# Altium schematic sheet Pedal Board - ADC.SchDoc("Pedal Board - ADC")
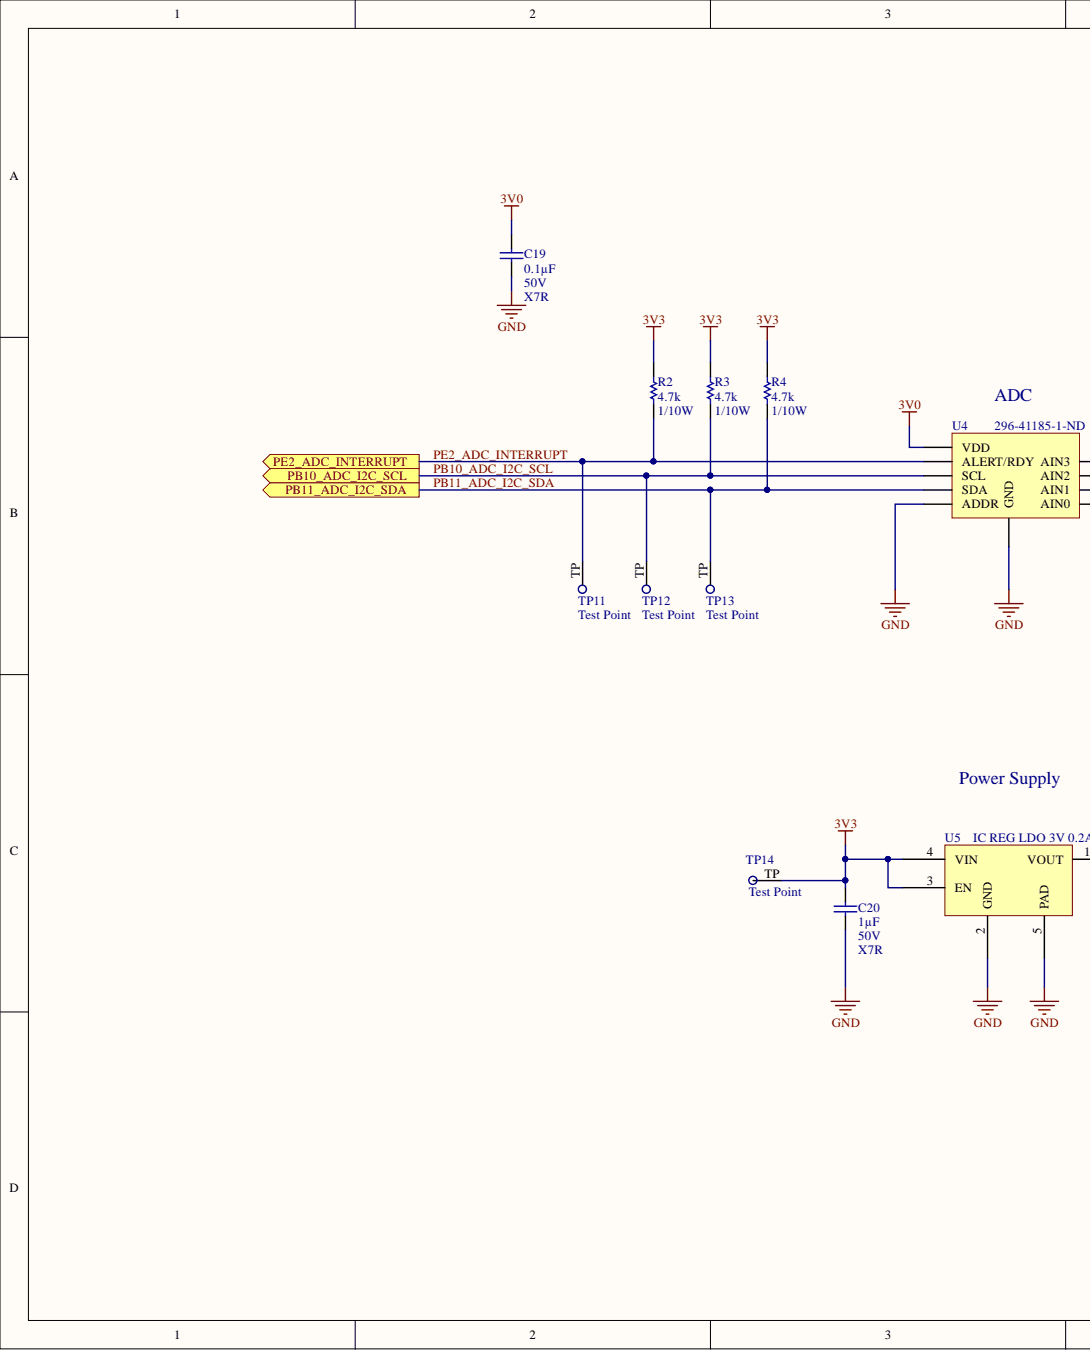
[diagram p0: Pedal Board - ADC.SchDoc, left]
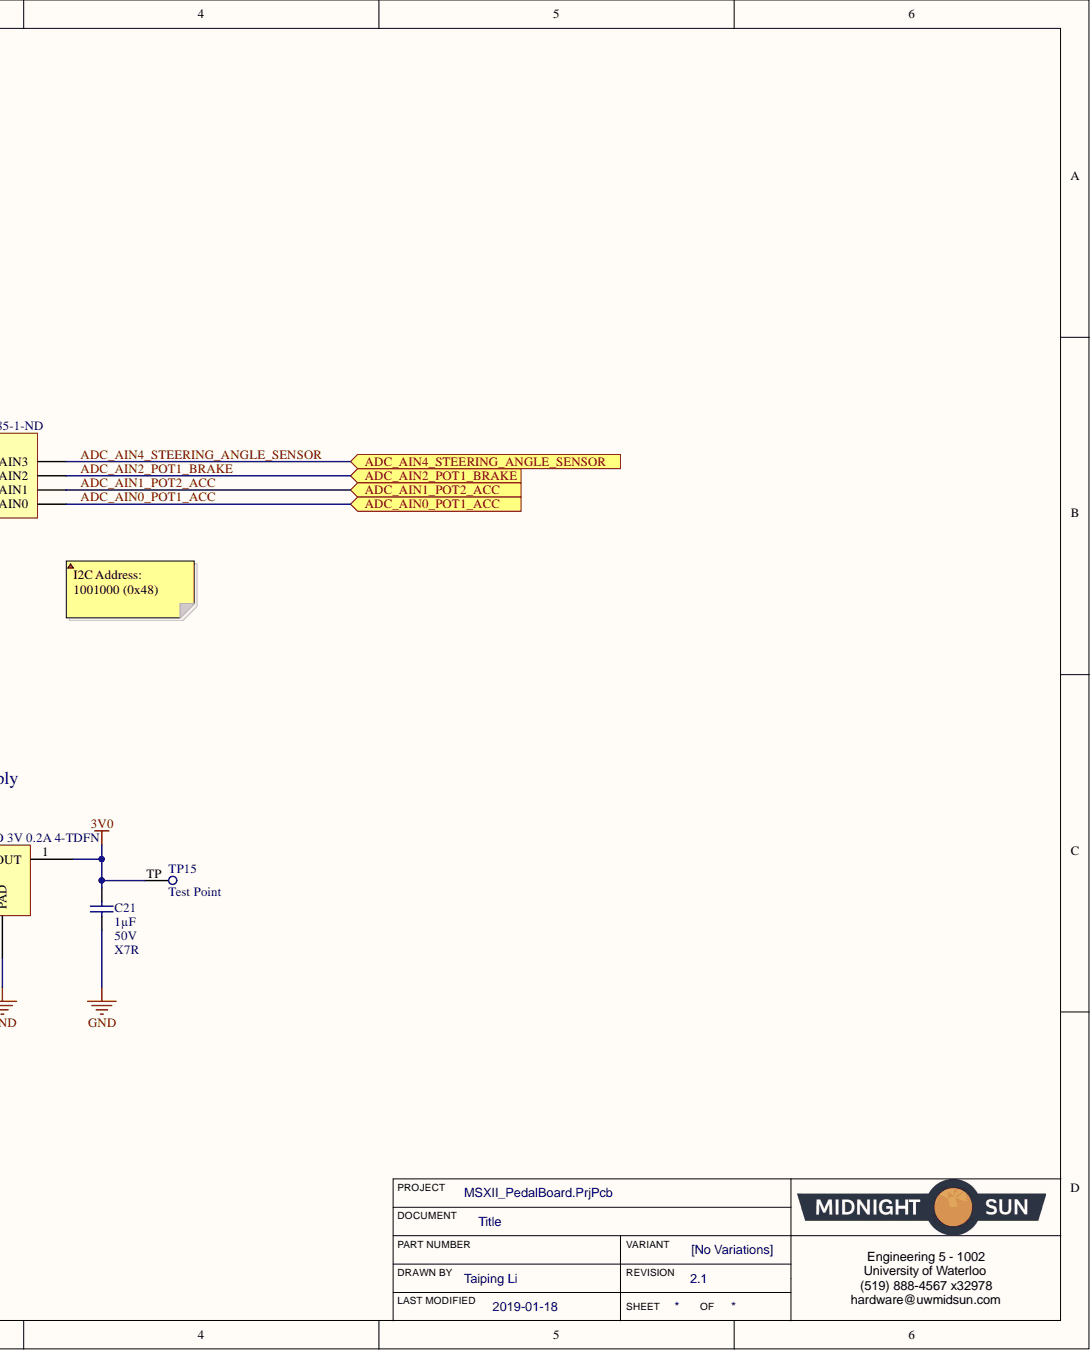
[diagram p1: Pedal Board - ADC.SchDoc, right]

## Parts
C19  Voltage: 50V  Temperature Coefficient: X7R  Capacitance: 0.1µF  [CAP, 0603]  (hidden comment: CAP CER 0.1UF 50V 10% X7R 0603)
C20  Voltage: 50V  Temperature Coefficient: X7R  Capacitance: 1µF  [CAP, 0603]  (hidden comment: CAP CER 1UF 50V 10% X7R 0603)
C21  Voltage: 50V  Temperature Coefficient: X7R  Capacitance: 1µF  [CAP, 0603]  (hidden comment: CAP CER 1UF 50V 10% X7R 0603)
R2  Resistance (Ohms): 4.7k  Power (Watts): 1/10W  [RES, 0603]  (hidden comment: RES 4.7K OHM 1% 1/10W 0603)
R3  Resistance (Ohms): 4.7k  Power (Watts): 1/10W  [RES, 0603]  (hidden comment: RES 4.7K OHM 1% 1/10W 0603)
R4  Resistance (Ohms): 4.7k  Power (Watts): 1/10W  [RES, 0603]  (hidden comment: RES 4.7K OHM 1% 1/10W 0603)
TP11  Test Point  [Test Point]  (no BOM)
TP12  Test Point  [Test Point]  (no BOM)
TP13  Test Point  [Test Point]  (no BOM)
TP14  Test Point  [Test Point]  (no BOM)
TP15  Test Point  [Test Point]  (no BOM)
U4  Supplier Part Number 1: 296-41185-1-ND  [IC ADC VSSOP10]  (hidden comment: Quad ADC)
U5  IC REG LDO 3V 0.2A 4-TDFN  Voltage - Output: 3V  [IC REG LDO 3V 0.2A 4-TDFN]

## Ports
ADC_AIN0_POT1_ACC, ADC_AIN1_POT2_ACC, ADC_AIN2_POT1_BRAKE, ADC_AIN4_STEERING_ANGLE_SENSOR, PB10_ADC_I2C_SCL, PB11_ADC_I2C_SDA, PE2_ADC_INTERRUPT

## Nets
3V0: C19.1 C21.1 TP15.TP U4.8(VDD) U5.1(VOUT)
3V3: C20.1 R2.1 R3.1 R4.1 TP14.TP U5.3(EN) U5.4(VIN)
GND: C19.2 C20.2 C21.2 U4.1(ADDR) U4.3(GND) U5.2(GND) U5.5(PAD)
ADC_AIN0_POT1_ACC: U4.4(AIN0) ; port ADC_AIN0_POT1_ACC
ADC_AIN1_POT2_ACC: U4.5(AIN1) ; port ADC_AIN1_POT2_ACC
ADC_AIN2_POT1_BRAKE: U4.6(AIN2) ; port ADC_AIN2_POT1_BRAKE
ADC_AIN4_STEERING_ANGLE_SENSOR: U4.7(AIN3) ; port ADC_AIN4_STEERING_ANGLE_SENSOR
PB10_ADC_I2C_SCL: R3.2 TP12.TP U4.10(SCL) ; port PB10_ADC_I2C_SCL
PB11_ADC_I2C_SDA: R4.2 TP13.TP U4.9(SDA) ; port PB11_ADC_I2C_SDA
PE2_ADC_INTERRUPT: R2.2 TP11.TP U4.2(ALERT/RDY) ; port PE2_ADC_INTERRUPT

## Library data of the parts
C19 = CAP CER 0.1UF 50V 10% X7R 0603: 0.10µF ±10% 50V Ceramic Capacitor X7R 0603 (1608 Metric); Manufacturer Part Number 1: 06035C104KAT2A; Manufacturer 1: AVX Corporation; Tolerance: ±10%; Thickness (Max): 0.035" (0.90mm); Supplier Part Number 1: 478-5052-1-ND; Supplier 1: Digi-Key; Size / Dimension: 0.063" L x 0.032" W (1.60mm x 0.81mm); Ratings: -; Part Status: Active; Packaging: Cut Tape (CT); Package / Case: 0603 (1608 Metric); Operating Temperature: -55°C ~ 125°C; Mounting Type: Surface Mount, MLCC; Lead Style: -; Lead Spacing: -; Height - Seated (Max): -; ComponentLink1URL: http://datasheets.avx.com/X7RDielectric.pdf; ComponentLink1Description: http://datasheets.avx.com/X7RDielectric.pdf; Component Kind: Standard; Library Name: Schematic Diagrams.SchLib
C20 C21 = CAP CER 1UF 50V 10% X7R 0603: 1µF ±10% 50V Ceramic Capacitor X7R 0603 (1608 Metric); Manufacturer Part Number 1: UMK107AB7105KA-T; Manufacturer 1: Taiyo Yuden; Tolerance: ±10%; Thickness (Max): 0.037" (0.95mm); Supplier Part Number 1: 587-3247-1-ND; Supplier 1: Digi-Key; Size / Dimension: 0.063" L x 0.031" W (1.60mm x 0.80mm); Ratings: -; Part Status: Active; Packaging: Cut Tape (CT); Package / Case: 0603 (1608 Metric); Operating Temperature: -55°C ~ 125°C; Mounting Type: Surface Mount, MLCC; Lead Style: -; Lead Spacing: -; Height - Seated (Max): -; ComponentLink1URL: http://www.yuden.co.jp/productdata/catalog/en/mlcc_all_e.pdf; ComponentLink1Description: http://www.yuden.co.jp/productdata/catalog/en/mlcc_all_e.pdf; Component Kind: Standard; Library Name: Schematic Diagrams.SchLib
R2-R4 = RES 4.7K OHM 1% 1/10W 0603: 4.7k Ohm ±1% 0.1W, 1/10W Chip Resistor 0603 (1608 Metric) Moisture Resistant Thick Film; Manufacturer Part Number 1: RC0603FR-074K7L; Manufacturer 1: Yageo; Temperature Coefficient: ±100ppm/°C; Description 1: RES SMD 4.7K OHM 1% 1/10W 0603; Tolerance: ±1%; Supplier Part Number 1: 311-4.70KHRCT-ND; Supplier Device Package: 0603; Supplier 1: Digi-Key; Stock 1: 3487566; Size / Dimension: 0.063" L x 0.031" W (1.60mm x 0.80mm); RoHS: RoHS Compliant; Pricing 1: 1=0.16, 10=0.022, 100=0.0089, 1000=0.00402, 2500=0.00349 (CAD); Part Status: Active; Packaging: Cut Tape (CT); Package / Case: 0603 (1608 Metric); Operating Temperature: -55°C ~ 155°C; Height - Seated (Max): 0.022" (0.55mm); Features: Moisture Resistant; Failure Rate: -; Composition: Thick Film; ComponentLink1URL: http://www.yageo.com/documents/recent/PYu-RC_Group_51_RoHS_L_7.pdf; ComponentLink1Description: http://www.yageo.com/documents/recent/PYu-RC_Group_51_RoHS_L_7.pdf; Component Kind: Standard; Category: Resistors; Library Name: Schematic Diagrams.SchLib
U4 = IC ADC 12-BIT VSSOP-10: 12 Bit Analog to Digital Converter 4 Input 1 Sigma-Delta 10-VSSOP; Manufacturer Part Number: ADS1015IDGSR; Manufacturer: Texas Instruments; Voltage - Supply, Digital: 2 V ~ 5.5 V; Sampling Rate (Per Second): 3.3k; Reference Type: Internal; Number of Inputs: 2, 4; Number of Bits: 12; Number of A/D Converters: 1; Input Type: Differential, Single Ended; Data Interface: I²C; Configuration: MUX-PGA-ADC; Voltage - Supply, Analog: 2 V ~ 5.5 V; Supplier Device Package: 10-VSSOP; Supplier 1: Digi-Key; Stock 1: 4084; RoHS: RoHS Compliant; Ratio - S/H: -; Pricing 1: 1=4.25, 10=3.807, 100=3.1194, 500=2.65552, 1000=2.23959 (CAD); Part Status: Active; Packaging: Cut Tape (CT); Package / Case: 10-TFSOP, 10-MSOP (0.118", 3.00mm Width); Operating Temperature: -40°C ~ 125°C; Features: PGA, Selectable Address; ComponentLink1URL: http://www.ti.com/lit/ds/symlink/ads1013.pdf; ComponentLink1Description: http://www.ti.com/lit/ds/symlink/ads1013.pdf; Component Kind: Standard; Category: Integrated Circuits (ICs); Architecture: Sigma-Delta; Library Name: Schematic Diagrams.SchLib
U5 = IC REG LDO 3V 0.2A 4-TDFN: Manufacturer Part Number: MIC94310-PYMT-TR; Manufacturer: Microchip Technology; Voltage - Input: Up to 3.6V; Voltage - Dropout (Typical): 0.04V @ 200mA; Regulator Topology: Positive Fixed; Current - Output: 200mA; Current - Limit (Min): 250mA; Supplier Part Number 1: 576-4761-1-ND; Supplier Device Package: 4-TDFN (1.2x1.6); Supplier 1: Digi-Key; Stock 1: 4802; RoHS: RoHS Compliant; Pricing 1: 1=0.45, 25=0.3708, 100=0.3386 (CAD); Part Status: Active; Packaging: Cut Tape (CT); Package / Case: 4-UFDFN Exposed Pad; Operating Temperature: -40°C ~ 125°C; Mounting Type: Surface Mount; ComponentLink1URL: http://www.microchip.com/mymicrochip/filehandler.aspx?ddocname=en580409; ComponentLink1Description: http://www.microchip.com/mymicrochip/filehandler.aspx?ddocname=en580409; Component Kind: Standard; Category: Integrated Circuits (ICs); Library Name: Schematic Diagrams.SchLib
TP11-TP15 = Test Point: Library Name: Schematic Diagrams.SchLib
